# Altium schematic sheet SSS Daughter Board Sheet1.SchDoc("SSS Daughter Board Sheet1")
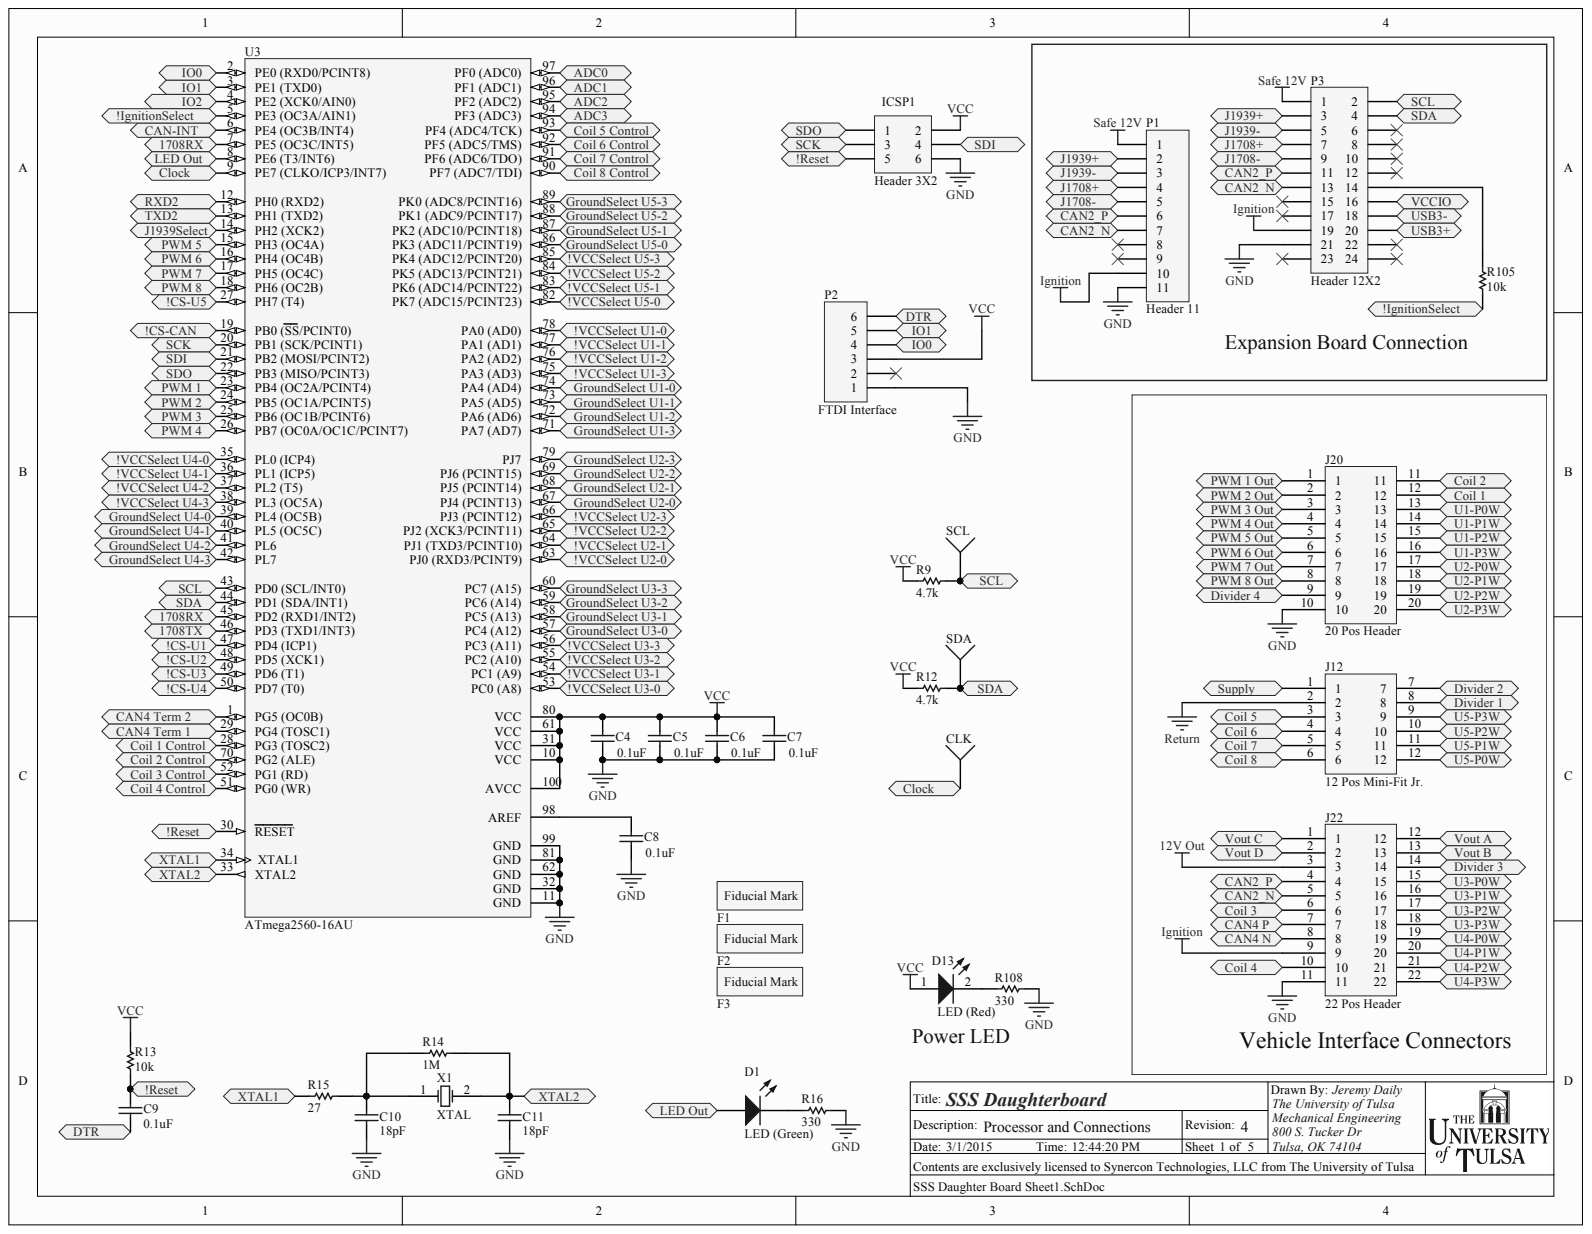
[diagram p0: SSS Daughter Board Sheet1.SchDoc]

## Parts
C4  0.1uF  [0603]
C5  0.1uF  [0603]
C6  0.1uF  [0603]
C7  0.1uF  [0603]
C8  0.1uF  [0603]
C9  0.1uF  [0603]
C10  18pF  [0603]
C11  18pF  [0603]
CLK  Test Point  [PIN1]  (value not drawn)
D1  LED (Green)  [0805 Polarized]
D13  LED (Red)  [0805 Polarized]
F1  Fiducials  [Fiducial]  (value not drawn)
F2  Fiducials  [Fiducial]  (value not drawn)
F3  Fiducials  [Fiducial]  (value not drawn)
ICSP1  Header 3X2  [ICSP Header (2x3)]
J12  12 Pos Mini-Fit Jr.  [12 pos Mini-Fit Jr. R/A Header]
J20  20 Pos Header  [20 pos Mini-Fit Jr. R/A Header]
J22  22 Pos Header  [22 pos Mini-Fit Jr. R/A Header]
P1  Header 11  [HDR1X11]
P2  FTDI Interface  [FTDI Header]
P3  Header 12X2  [HDR2X12]
R9  4.7k  [0805]
R12  4.7k  [0805]
R13  10k  [0805]
R14  1M  [0805]
R15  27  [0805]
R16  330  [0805]
R105  10k  [0805]
R108  330  [0805]
SCL  Test Point  [PIN1]  (value not drawn)
SDA  Test Point  [PIN1]  (value not drawn)
U3  ATmega2560-16AU  [100A_M]
X1  XTAL  [XTAL 2 pad]

## Ports
1708RX, 1708TX, !CS-CAN, !CS-U1, !CS-U2, !CS-U3, !CS-U4, !CS-U5, !IGNITIONSELECT, !RESET, !VCCSELECT U1-0, !VCCSELECT U1-1, !VCCSELECT U1-2, !VCCSELECT U1-3, !VCCSELECT U2-0, !VCCSELECT U2-1, !VCCSELECT U2-2, !VCCSELECT U2-3, !VCCSELECT U3-0, !VCCSELECT U3-1, !VCCSELECT U3-2, !VCCSELECT U3-3, !VCCSELECT U4-0, !VCCSELECT U4-1, !VCCSELECT U4-2, !VCCSELECT U4-3, !VCCSELECT U5-0, !VCCSELECT U5-1, !VCCSELECT U5-2, !VCCSELECT U5-3, ADC0, ADC1, ADC2, ADC3, CAN2_N, CAN2_P, CAN4 N, CAN4 P, CAN4 TERM 1, CAN4 TERM 2, CAN-INT, CLOCK, COIL 1, COIL 1 CONTROL, COIL 2, COIL 2 CONTROL, COIL 3, COIL 3 CONTROL, COIL 4, COIL 4 CONTROL, COIL 5, COIL 5 CONTROL, COIL 6, COIL 6 CONTROL, COIL 7, COIL 7 CONTROL, COIL 8, COIL 8 CONTROL, DIVIDER 1, DIVIDER 2, DIVIDER 3, DIVIDER 4, DTR, GROUNDSELECT U1-0, GROUNDSELECT U1-1, GROUNDSELECT U1-2, GROUNDSELECT U1-3, GROUNDSELECT U2-0, GROUNDSELECT U2-1, GROUNDSELECT U2-2, GROUNDSELECT U2-3, GROUNDSELECT U3-0, GROUNDSELECT U3-1, GROUNDSELECT U3-2, GROUNDSELECT U3-3, GROUNDSELECT U4-0, GROUNDSELECT U4-1, GROUNDSELECT U4-2, GROUNDSELECT U4-3, GROUNDSELECT U5-0, GROUNDSELECT U5-1, GROUNDSELECT U5-2, GROUNDSELECT U5-3, IO0, IO1, IO2, J1708+, J1708-, J1939+, J1939-, J1939SELECT, LED OUT, PWM 1, PWM 1 OUT, PWM 2, PWM 2 OUT, PWM 3, PWM 3 OUT, PWM 4, PWM 4 OUT, PWM 5, PWM 5 OUT, PWM 6, PWM 6 OUT, PWM 7, PWM 7 OUT, PWM 8, PWM 8 OUT, RXD2, SCK, SCL, SDA, SDI, SDO, SUPPLY, TXD2, U1-P0W, U1-P1W, U1-P2W, U1-P3W, U2-P0W, U2-P1W, U2-P2W, U2-P3W, U3-P0W, U3-P1W, U3-P2W, U3-P3W, U4-P0W, U4-P1W, U4-P2W, U4-P3W, U5-P0W, U5-P1W, U5-P2W, U5-P3W, USB3+, USB3-, VCCIO, VOUT A, VOUT B, VOUT C, VOUT D, XTAL1, XTAL2

## Nets
12V OUT: J22.3
GND: C4.2 C5.2 C6.2 C7.2 C8.2 C10.1 C11.1 ICSP1.6 J20.10 J22.11 P1.11 P2.1 P3.21 R16.2 R108.2 U3.11(GND) U3.32(GND) U3.62(GND) U3.81(GND) U3.99(GND)
IGNITION: J22.9 P1.10 P3.19
RETURN: J12.2
SAFE 12V: P1.1 P3.1
VCC: C4.1 C5.1 C6.1 C7.1 D13.1 ICSP1.2 P2.3 R9.2 R12.2 R13.1 U3.10(VCC) U3.31(VCC) U3.61(VCC) U3.80(VCC) U3.100(AVCC)
1708RX: U3.7(PE5_(OC3C/INT5)) U3.45(PD2_(RXD1/INT2)) ; port 1708RX
1708TX: U3.46(PD3_(TXD1/INT3)) ; port 1708TX
!CS-CAN: U3.19(PB0_(SS/PCINT0)) ; port !CS-CAN
!CS-U1: U3.47(PD4_(ICP1)) ; port !CS-U1
!CS-U2: U3.48(PD5_(XCK1)) ; port !CS-U2
!CS-U3: U3.49(PD6_(T1)) ; port !CS-U3
!CS-U4: U3.50(PD7_(T0)) ; port !CS-U4
!CS-U5: U3.27(PH7_(T4)) ; port !CS-U5
ADC0: U3.97(PF0_(ADC0)) ; port ADC0
ADC1: U3.96(PF1_(ADC1)) ; port ADC1
ADC2: U3.95(PF2_(ADC2)) ; port ADC2
ADC3: U3.94(PF3_(ADC3)) ; port ADC3
CAN2_N: J22.5 P1.7 P3.13 ; port CAN2_N
CAN2_P: J22.4 P1.6 P3.11 ; port CAN2_P
CAN4 N: J22.8 ; port CAN4 N
CAN4 P: J22.7 ; port CAN4 P
CAN-INT: U3.6(PE4_(OC3B/INT4)) ; port CAN-INT
DTR: C9.2 P2.6 ; port DTR
IO0: P2.4 U3.2(PE0_(RXD0/PCINT8)) ; port IO0
IO1: P2.5 U3.3(PE1_(TXD0)) ; port IO1
IO2: U3.4(PE2_(XCK0/AIN0)) ; port IO2
PWM 1: U3.23(PB4_(OC2A/PCINT4)) ; port PWM 1
PWM 2: U3.24(PB5_(OC1A/PCINT5)) ; port PWM 2
PWM 3: U3.25(PB6_(OC1B/PCINT6)) ; port PWM 3
PWM 4: U3.26(PB7_(OC0A/OC1C/PCINT7)) ; port PWM 4
PWM 5: U3.15(PH3_(OC4A)) ; port PWM 5
PWM 6: U3.16(PH4_(OC4B)) ; port PWM 6
PWM 7: U3.17(PH5_(OC4C)) ; port PWM 7
PWM 8: U3.18(PH6_(OC2B)) ; port PWM 8
RXD2: U3.12(PH0_(RXD2)) ; port RXD2
SCK: ICSP1.3 U3.20(PB1_(SCK/PCINT1)) ; port SCK
SCL: P3.2 R9.1 SCL.1 U3.43(PD0_(SCL/INT0)) ; port SCL
SDA: P3.4 R12.1 SDA.1 U3.44(PD1_(SDA/INT1)) ; port SDA
SDI: ICSP1.4 U3.21(PB2_(MOSI/PCINT2)) ; port SDI
SDO: ICSP1.1 U3.22(PB3_(MISO/PCINT3)) ; port SDO
TXD2: U3.13(PH1_(TXD2)) ; port TXD2
U1-P0W: J20.13 ; port U1-P0W
U1-P1W: J20.14 ; port U1-P1W
U1-P2W: J20.15 ; port U1-P2W
U1-P3W: J20.16 ; port U1-P3W
U2-P0W: J20.17 ; port U2-P0W
U2-P1W: J20.18 ; port U2-P1W
U2-P2W: J20.19 ; port U2-P2W
U2-P3W: J20.20 ; port U2-P3W
U3-P0W: J22.15 ; port U3-P0W
U3-P1W: J22.16 ; port U3-P1W
U3-P2W: J22.17 ; port U3-P2W
U3-P3W: J22.18 ; port U3-P3W
U4-P0W: J22.19 ; port U4-P0W
U4-P1W: J22.20 ; port U4-P1W
U4-P2W: J22.21 ; port U4-P2W
U4-P3W: J22.22 ; port U4-P3W
U5-P0W: J12.12 ; port U5-P0W
U5-P1W: J12.11 ; port U5-P1W
U5-P2W: J12.10 ; port U5-P2W
U5-P3W: J12.9 ; port U5-P3W
VCCIO: P3.16 ; port VCCIO
XTAL1: R15.2 U3.34(XTAL1) ; port XTAL1
XTAL2: C11.2 R14.1 U3.33(XTAL2) X1.2 ; port XTAL2
NetC8_1: C8.1 U3.98(AREF)
NetC9_1: C9.1 ICSP1.5 R13.2 U3.30(RESET) ; port !RESET
NetC10_2: C10.2 R14.2 R15.1 X1.1
NetCLK_1: CLK.1 U3.9(PE7_(CLKO/ICP3/INT7)) ; port CLOCK
NetD1_1: D1.1 U3.8(PE6_(T3/INT6)) ; port LED OUT
NetD1_2: D1.2 R16.1
NetD13_2: D13.2 R108.1
NetJ12_1: J12.1 ; port SUPPLY
NetJ12_3: J12.3 ; port COIL 5
NetJ12_4: J12.4 ; port COIL 6
NetJ12_5: J12.5 ; port COIL 7
NetJ12_6: J12.6 ; port COIL 8
NetJ12_7: J12.7 ; port DIVIDER 2
NetJ12_8: J12.8 ; port DIVIDER 1
NetJ20_1: J20.1 ; port PWM 1 OUT
NetJ20_2: J20.2 ; port PWM 2 OUT
NetJ20_3: J20.3 ; port PWM 3 OUT
NetJ20_4: J20.4 ; port PWM 4 OUT
NetJ20_5: J20.5 ; port PWM 5 OUT
NetJ20_6: J20.6 ; port PWM 6 OUT
NetJ20_7: J20.7 ; port PWM 7 OUT
NetJ20_8: J20.8 ; port PWM 8 OUT
NetJ20_9: J20.9 ; port DIVIDER 4
NetJ20_11: J20.11 ; port COIL 2
NetJ20_12: J20.12 ; port COIL 1
NetJ22_1: J22.1 ; port VOUT C
NetJ22_2: J22.2 ; port VOUT D
NetJ22_6: J22.6 ; port COIL 3
NetJ22_10: J22.10 ; port COIL 4
NetJ22_12: J22.12 ; port VOUT A
NetJ22_13: J22.13 ; port VOUT B
NetJ22_14: J22.14 ; port DIVIDER 3
NetP1_2: P1.2 P3.3
NetP1_3: P1.3 P3.5
NetP1_4: P1.4 P3.7
NetP1_5: P1.5 P3.9
NetP3_14: P3.14 R105.1
NetP3_18: P3.18
NetP3_20: P3.20
NetR105_2: R105.2 U3.5(PE3_(OC3A/AIN1)) ; port !IGNITIONSELECT
NetU3_1: U3.1(PG5_(OC0B)) ; port CAN4 TERM 2
NetU3_14: U3.14(PH2_(XCK2)) ; port J1939SELECT
NetU3_28: U3.28(PG3_(TOSC2)) ; port COIL 1 CONTROL
NetU3_29: U3.29(PG4_(TOSC1)) ; port CAN4 TERM 1
NetU3_35: U3.35(PL0_(ICP4)) ; port !VCCSELECT U4-0
NetU3_36: U3.36(PL1_(ICP5)) ; port !VCCSELECT U4-1
NetU3_37: U3.37(PL2_(T5)) ; port !VCCSELECT U4-2
NetU3_38: U3.38(PL3_(OC5A)) ; port !VCCSELECT U4-3
NetU3_39: U3.39(PL4_(OC5B)) ; port GROUNDSELECT U4-0
NetU3_40: U3.40(PL5_(OC5C)) ; port GROUNDSELECT U4-1
NetU3_41: U3.41(PL6) ; port GROUNDSELECT U4-2
NetU3_42: U3.42(PL7) ; port GROUNDSELECT U4-3
NetU3_51: U3.51(PG0_(WR)) ; port COIL 4 CONTROL
NetU3_52: U3.52(PG1_(RD)) ; port COIL 3 CONTROL
NetU3_53: U3.53(PC0_(A8)) ; port !VCCSELECT U3-0
NetU3_54: U3.54(PC1_(A9)) ; port !VCCSELECT U3-1
NetU3_55: U3.55(PC2_(A10)) ; port !VCCSELECT U3-2
NetU3_56: U3.56(PC3_(A11)) ; port !VCCSELECT U3-3
NetU3_57: U3.57(PC4_(A12)) ; port GROUNDSELECT U3-0
NetU3_58: U3.58(PC5_(A13)) ; port GROUNDSELECT U3-1
NetU3_59: U3.59(PC6_(A14)) ; port GROUNDSELECT U3-2
NetU3_60: U3.60(PC7_(A15)) ; port GROUNDSELECT U3-3
NetU3_63: U3.63(PJ0_(RXD3/PCINT9)) ; port !VCCSELECT U2-0
NetU3_64: U3.64(PJ1_(TXD3/PCINT10)) ; port !VCCSELECT U2-1
NetU3_65: U3.65(PJ2_(XCK3/PCINT11)) ; port !VCCSELECT U2-2
NetU3_66: U3.66(PJ3_(PCINT12)) ; port !VCCSELECT U2-3
NetU3_67: U3.67(PJ4_(PCINT13)) ; port GROUNDSELECT U2-0
NetU3_68: U3.68(PJ5_(PCINT14)) ; port GROUNDSELECT U2-1
NetU3_69: U3.69(PJ6_(PCINT15)) ; port GROUNDSELECT U2-2
NetU3_70: U3.70(PG2_(ALE)) ; port COIL 2 CONTROL
NetU3_71: U3.71(PA7_(AD7)) ; port GROUNDSELECT U1-3
NetU3_72: U3.72(PA6_(AD6)) ; port GROUNDSELECT U1-2
NetU3_73: U3.73(PA5_(AD5)) ; port GROUNDSELECT U1-1
NetU3_74: U3.74(PA4_(AD4)) ; port GROUNDSELECT U1-0
NetU3_75: U3.75(PA3_(AD3)) ; port !VCCSELECT U1-3
NetU3_76: U3.76(PA2_(AD2)) ; port !VCCSELECT U1-2
NetU3_77: U3.77(PA1_(AD1)) ; port !VCCSELECT U1-1
NetU3_78: U3.78(PA0_(AD0)) ; port !VCCSELECT U1-0
NetU3_79: U3.79(PJ7) ; port GROUNDSELECT U2-3
NetU3_82: U3.82(PK7_(ADC15/PCINT23)) ; port !VCCSELECT U5-0
NetU3_83: U3.83(PK6_(ADC14/PCINT22)) ; port !VCCSELECT U5-1
NetU3_84: U3.84(PK5_(ADC13/PCINT21)) ; port !VCCSELECT U5-2
NetU3_85: U3.85(PK4_(ADC12/PCINT20)) ; port !VCCSELECT U5-3
NetU3_86: U3.86(PK3_(ADC11/PCINT19)) ; port GROUNDSELECT U5-0
NetU3_87: U3.87(PK2_(ADC10/PCINT18)) ; port GROUNDSELECT U5-1
NetU3_88: U3.88(PK1_(ADC9/PCINT17)) ; port GROUNDSELECT U5-2
NetU3_89: U3.89(PK0_(ADC8/PCINT16)) ; port GROUNDSELECT U5-3
NetU3_90: U3.90(PF7_(ADC7/TDI)) ; port COIL 8 CONTROL
NetU3_91: U3.91(PF6_(ADC6/TDO)) ; port COIL 7 CONTROL
NetU3_92: U3.92(PF5_(ADC5/TMS)) ; port COIL 6 CONTROL
NetU3_93: U3.93(PF4_(ADC4/TCK)) ; port COIL 5 CONTROL

Not connected: P1 8 9; P2 2; P3 6 8 10 12 15 17 22 23 24

## Library data of the parts
C4-C11 = Cap: Component Kind: Standard; Signal Integrity: Cap; Simulation: CAP; Library Name: Daily_Integrated_Library.IntLib
  C4-C9: Value: 0.1uF
  C10 C11: Value: 18pF
CLK SCL SDA = Socket: Component Kind: Standard; Library Name: Miscellaneous Connectors.IntLib; Signal Integrity: Connector
  CLK: Value: Test Point
D1 D13 = SMT LED: Component Kind: Standard; Library Name: KongsLib.IntLib
J12 = 12 Pos Header: Component Kind: Standard; Library Name: Mini Fit Jr.SchLib
P2 = Header 6: Component Kind: Standard; Library Name: Miscellaneous Connectors.IntLib; Signal Integrity: Connector
R9 R12-R16 R108 = Chip Resistor: Component Kind: Standard
  R9 R12 R14-R16: Library Name: KongsLib.IntLib
  R13: Library Name: KongsLib.SchLib
  R108: Library Name: Daily_Integrated_Library.IntLib
R105 = SMD Resistor: Component Kind: Standard; Library Name: Resistors.IntLib
X1 = Crystal Oscillator: Component Kind: Standard; Library Name: KongsLib.IntLib
F1-F3 = Fiducials: Component Kind: Standard; Library Name: SSS Schematic Library.SchLib
ICSP1 = Header 3X2: Component Kind: Standard; Library Name: Miscellaneous Connectors.IntLib; Signal Integrity: Connector
J20 = 20 Pos Header: Component Kind: Standard; Library Name: Mini Fit Jr.SchLib
J22 = 22 Pos Header: Component Kind: Standard; Library Name: Mini Fit Jr.SchLib
P1 = Header 11: Component Kind: Standard; Library Name: Miscellaneous Connectors.IntLib; Signal Integrity: Connector
P3 = Header 12X2: Component Kind: Standard; Library Name: Miscellaneous Connectors.IntLib; Signal Integrity: Connector
U3 = ATmega2560-16AU: Component Kind: Standard; Library Name: Atmel Microcontroller 8-Bit megaAVR.IntLib; Supplier 1: Digi-Key; Supplier Part Number 1: ATMEGA2560-16AU-ND
